# Altium schematic sheet BalanceBug.SchDoc(BalanceBug)
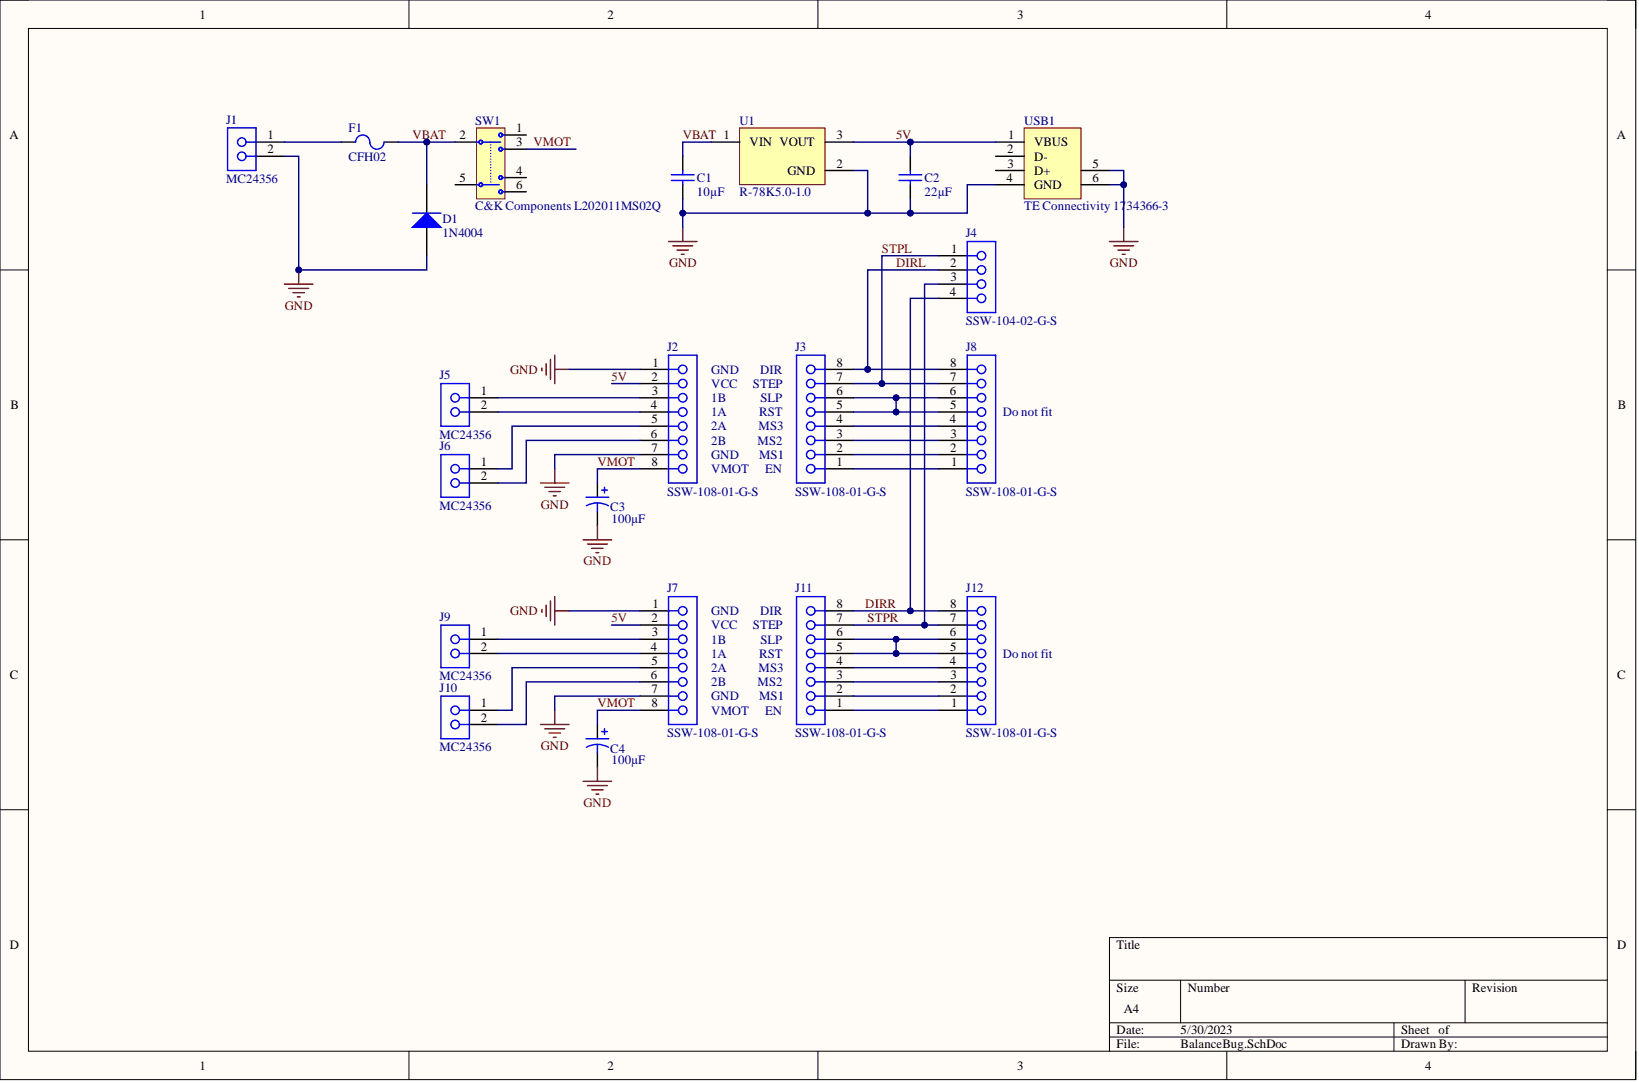
[diagram p0: BalanceBug.SchDoc]

## Parts
C1  Capacitance: 10µF  (hidden comment: Kemet C330C105K5R5TA)
C2  Capacitance: 22µF  (hidden comment: Kemet C330C105K5R5TA)
C3  100µF
C4  100µF
D1  1N4004
F1  CFH02
J1  MC24356
J2  SSW-108-01-G-S
J3  SSW-108-01-G-S
J4  SSW-104-02-G-S
J5  MC24356
J6  MC24356
J7  SSW-108-01-G-S
J8  SSW-108-01-G-S
J9  MC24356
J10  MC24356
J11  SSW-108-01-G-S
J12  SSW-108-01-G-S
SW1  C&K Components L202011MS02Q  [PCB-p1yaf63lu2p58ziuahzv-1]
U1  R-78K5.0-1.0
USB1  TE Connectivity 1734366-3

## Nets
GND: C1.1 C2.1 C3.2 C4.2 D1.1 J1.2 J2.1 J2.7 J7.1 J7.7 U1.2 USB1.4(GND) USB1.5 USB1.6
5V: C2.2 J2.2 J7.2 U1.3 USB1.1(VBUS)
DIRL: J3.8 J4.2 J8.8
DIRR: J4.4 J11.8 J12.8
STPL: J3.7 J4.1 J8.7
STPR: J4.3 J11.7 J12.7
VBAT: C1.2 D1.2 F1.2 SW1.2 U1.1
VMOT: C3.1 C4.1 J2.8 J7.8 SW1.3
NetF1_1: F1.1 J1.1
NetJ2_3: J2.3 J5.1
NetJ2_4: J2.4 J5.2
NetJ2_5: J2.5 J6.1
NetJ2_6: J2.6 J6.2
NetJ3_1: J3.1 J8.1
NetJ3_2: J3.2 J8.2
NetJ3_3: J3.3 J8.3
NetJ3_4: J3.4 J8.4
NetJ3_5: J3.5 J3.6 J8.5 J8.6
NetJ7_3: J7.3 J9.1
NetJ7_4: J7.4 J9.2
NetJ7_5: J7.5 J10.1
NetJ7_6: J7.6 J10.2
NetJ11_1: J11.1 J12.1
NetJ11_2: J11.2 J12.2
NetJ11_3: J11.3 J12.3
NetJ11_4: J11.4 J12.4
NetJ11_5: J11.5 J11.6 J12.5 J12.6

Not connected: SW1 1 4 5 6; USB1 2(D-) 3(D+)

## Library data of the parts
C1 C2 = CMP-bb267075e97664e1-5: Capacitor; Ceramic; Cap 1.00uF; Tol 10%; Radial; Vol-Rtg 50V; Component Kind: Standard; Library Name: My Personal Space; Octopart URL: https://octopart.com/c330c105k5r5ta-kemet-39417654
C3 C4 = CMP-1b08d3184bfdc4cf-1: Cap Aluminum Lytic 100uF 16V 20% (6.3 X 11.2mm) Radial 2.5mm 290mA 1000h 105C Ammo Pack; Manufacturer Part Number: EEU-FC1C101H; Component Kind: Standard; Library Name: CommunityVault; Lifecycle Status: Not Listed by Manufacturer; Octopart URL: http://octopart.com/eeu-fc1c101h-panasonic-18511251
D1 = CMP-816519-3: Standard Recovery Rectifier, 2-Pin Axial_Lead, Pb-Free, Tape and Reel; Current Rating: 1.0 A; Lead-Free Status: Lead Free; Voltage Rating (DC): 50.0 V; Case/Package: DO-41; Component Kind: Standard; Halogen Free Status: Halogen Free; Library Name: CommunityVault; Lifecycle Status: Active; Mounting Style: Axial; Number of Pins: 2; Octopart Url: https://octopart.com/1n4001rlg-on+semiconductor-322109; OctopartID: 8cde45d043855319; Packaging: Cut Tape (CT); Polarity: Standard; REACH SVHC Compliance: No SVHC; RoHS: Compliant
F1 = CMP-jsq7mpiehlwut1rbuuav-4: FUSEHOLDER, PCB, 5X20MM; Manufacturer: CamdenBoss; Component Kind: Standard; CustomComponentID: jsq7mpiehlwut1rbuuav; Library Name: CommunityVault; Part No: CFH02
J1 J5 J6 J9 J10 = CMP-19300950-8: Wire-To-Board Terminal Block, 5 mm, 2 Ways, 24 AWG, 16 AWG, Screw; Number of Contacts: 2; Component Kind: Standard; Contact Material: Brass; Contact Plating: Tin; Library Name: CommunityVault; Manufacturer Part Number: MC24356; Mounting Style: PCB; Octopart URL: http://octopart.com/mc24356-multicomp-8211225; OctopartID: 7d95b4e213eaa252; RoHS: Compliant
J2 J3 J7 J8 J11 J12 = CMP-678528-2: SOCKET, Through-hole, Vertical, -55 to 125 degC, 2.54 mm Pitch, 8-Pin Female, RoHS; Contact Resistance: 0.01 Ω; Lead-Free Status: Lead Free; Number of Contacts: 8; Number of Mating Cycles: 100; Pin Pitch: 0.00254 m; Color: Black; Component Kind: Standard; Contact Material: Phosphor Bronze; Contact Plating: Gold; Gender: Female; Housing Color: Black; Housing Material: Plastic; Library Name: My Personal Space; Manufacturer Part Number: SSW-108-01-G-S; Mounting Style: Through Hole; Number of Positions: 8; Number of Rows: 1; Octopart Url: https://octopart.com/ssw-108-01-g-s-samtec-296063; OctopartID: ee7a27744f6331bd; Packaging: Tube; REACH SVHC Compliance: No SVHC; RoHS: Yes; Size-Height: 0.00851 m; Size-Length: 0.02083 m; Size-Width: 0.00241 m
J4 = CMP-2f26e6288f97f0e1-3: Conn Socket Strip SKT 4 POS 2.54mm Solder ST Thru-Hole; Contact Resistance: 10.0 mΩ; Gender: Female; Lead-Free Status: Lead Free; Number of Contacts: 4; Number of Mating Cycles: 100; Color: Black; Component Kind: Standard; Contact Material: Phosphor Bronze; Contact Plating: Gold; Housing Color: Black; Housing Material: Plastic; Library Name: CommunityVault; Manufacturer Part Number: SSW-104-02-G-S; Mounting Style: Through Hole; Number of Positions: 4; Number of Rows: 1; Octopart URL: http://octopart.com/ssw-104-02-g-s-samtec-296407; OctopartID: 2f26e6288f97f0e1; Packaging: Tube; Pin Pitch: 2.54 mm; REACH SVHC Compliance: No SVHC; RoHS: Yes; Size-Height: 8.51 mm; Size-Length: 10.7 mm; Size-Width: 2.41 mm
SW1 = CMP-ced207fd1a241115-6: Switch, Slide, Miniature, DPDT, 4A@125VAC; 2A@250VAC; 0.5A@125VDC; Manufacturer Part Number: MFP  221 N; Current Rating: 6.00 A; Lead-Free Status: Lead Free; Component Kind: Standard; Contact Material: Silver; Contacts Type: DPDT; Library Name: My Personal Space; Mounting Style: Through Hole; Octopart URL: http://octopart.com/l202011ms02q-c%26k+components-2486672; OctopartID: ced207fd1a241115; Orientation: Right Angle; REACH SVHC Compliance: No SVHC; RoHS: Compliant
U1 = CMP-1497443-2: 1000 mA, Low Voltage, Low Quiescent Current LDO Regulator, 3-Pin TO-220, Extended Temperature; Manufacturer Part Number: MCP1826S-5002E/AB; Dropout Voltage: 0.25 V; Lead-Free Status: Lead Free; Number of Outputs: 1; Output Capacitor Type: Ceramic; Quiescent Current: 0.00012 A; Component Kind: Standard; Library Name: CommunityVault; Lifecycle Status: Active; Mounting Style: Through Hole; Number of Pins: 3; Octopart Url: https://octopart.com/mcp1826s-5002e%2Fab-microchip-7315913; OctopartID: fa79331c7ed625be; REACH SVHC Compliance: No SVHC; RoHS: Compliant
USB1 = 1734366-3: Conn USB 2.0 Type A RCP 4 POS Solder ST Thru-Hole 4 Terminal 1 Port Tray; ELV Compliant: Compliant; Gender: Female; Lead-Free Status: Lead Free; Component Kind: Standard; Contact Plating: Gold Flash, Gold Flash over Nickel; Housing Color: Blue; Housing Material: Thermoplastic; Library Name: Octopart library; Lifecycle Status: Active; Manufacturer Part Number: 1734366-3; Octopart URL: http://octopart.com/1734366-3-te+connectivity-44330297; OctopartID: 27c8ec666f97f80f; Packaging: Tray; RoHS: Compliant
